# Altium schematic sheet Sheet3.SchDoc
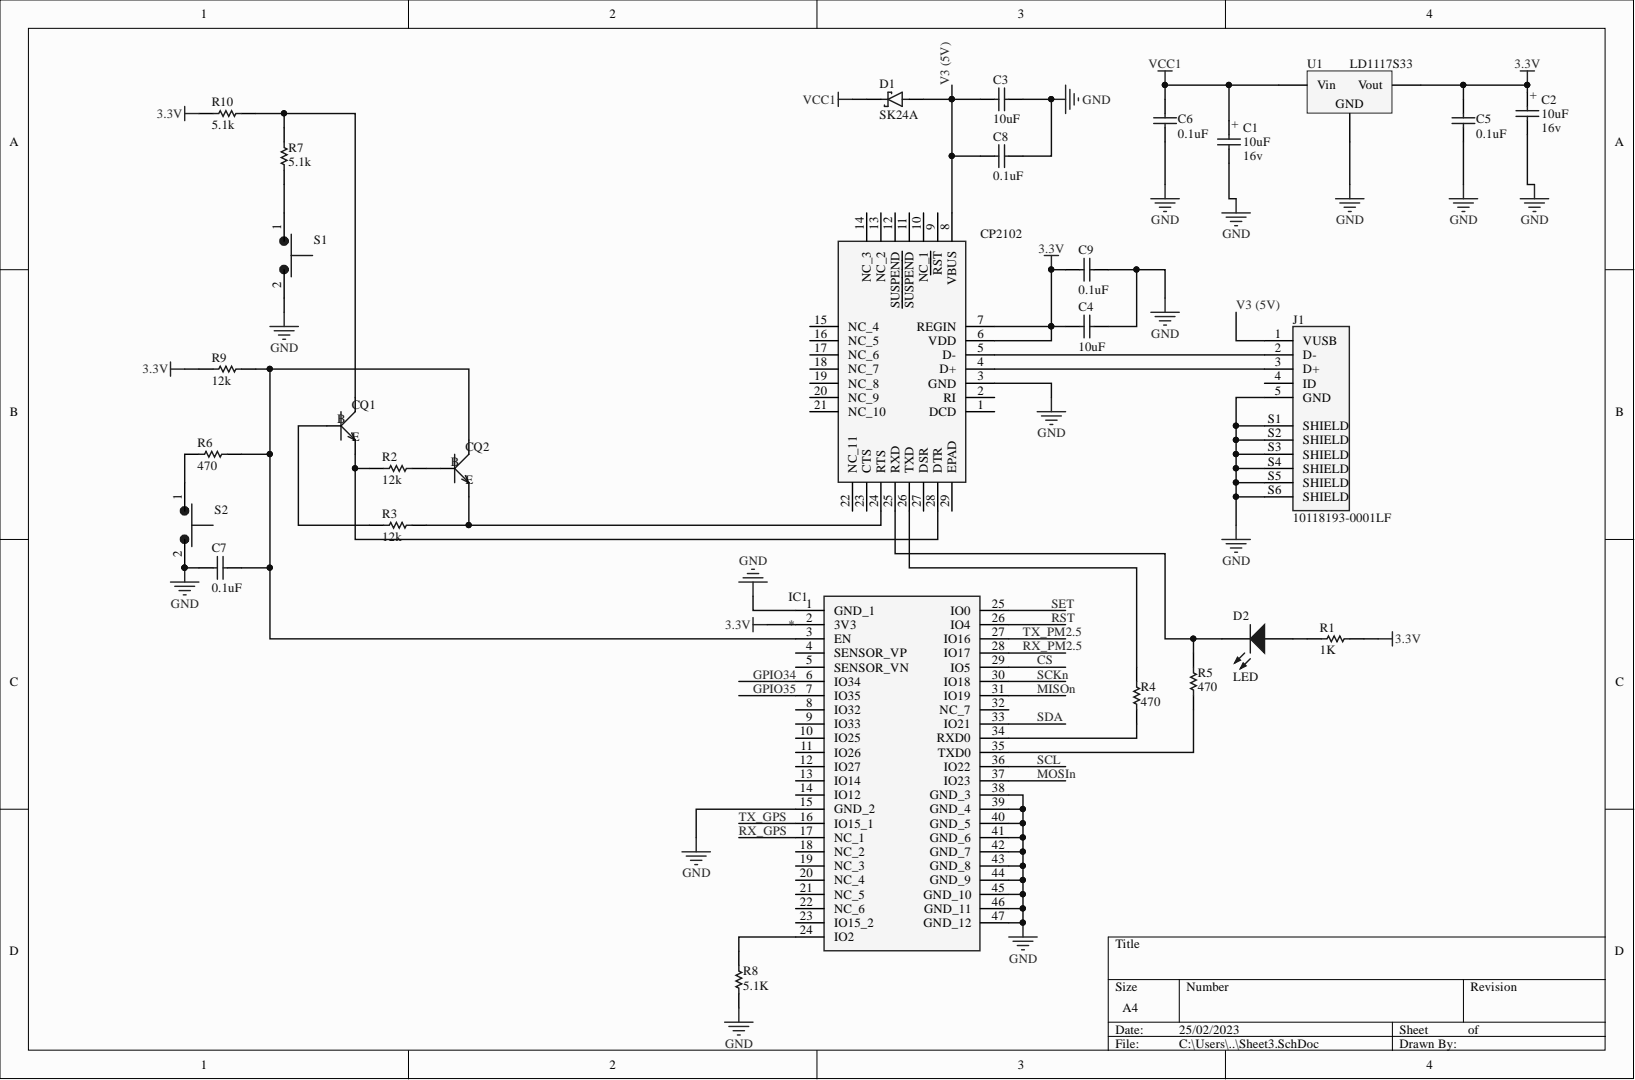
[diagram p0: Sheet3.SchDoc]

## Parts
C1  10uF  Volte: 16v  [MY_SMC_C]
C2  10uF  Volte: 16v  [MY_SMC_C]
C3  10uF  [MY_0603]
C4  10uF  [MY_0603]
C5  0.1uF  [MY_0603]
C6  0.1uF  [MY_0603]
C7  0.1uF  [MY_0603]
C8  0.1uF  [MY_0603]
C9  0.1uF  [MY_0603]
D1  SK24A  [DIOM4325X250N]
D2  LED  [MY_LED_0805]
IC1  (no value)  [ESP32WROOM32UEM113EH2800UH3Q0]
IC2  CP2102  [QFN50P500X500X100-29N-D]
J1  10118193-0001LF  [FCI_10118193-0001LF]
Q1  (no value)  [SOT-23]
Q2  (no value)  [SOT-23]
R1  1K  [MY_0603]
R2  12k  [MY_0603]
R3  12k  [MY_0603]
R4  470  [MY_0603]
R5  470  [MY_0603]
R6  470  [MY_0603]
R7  5.1k  [MY_0603]
R8  5.1K  [MY_0603]
R9  12k  [MY_0603]
R10  5.1k  [MY_0603]
S1  TL1015AF160QG  [SW_TL1015AF160QG]  (value not drawn)
S2  TL1015AF160QG  [SW_TL1015AF160QG]  (value not drawn)
U1  LD1117S33  [MY_SOT223]

## Nets
3.3V: C2.+ C4.2 C5.2 C9.2 IC1.2(3V3) IC2.6(VDD) IC2.7(REGIN) R1.2 R9.1 R10.1 U1.2
GND: C1.- C2.- C3.1 C4.1 C5.1 C6.1 C7.2 C8.1 C9.1 IC1.1(GND_1) IC1.15(GND_2) IC1.38(GND_3) IC1.39(GND_4) IC1.40(GND_5) IC1.41(GND_6) IC1.42(GND_7) IC1.43(GND_8) IC1.44(GND_9) IC1.45(GND_10) IC1.46(GND_11) IC1.47(GND_12) IC2.3(GND) J1.5(GND) J1.S1(SHIELD) J1.S2(SHIELD) J1.S3(SHIELD) J1.S4(SHIELD) J1.S5(SHIELD) J1.S6(SHIELD) R8.1 S1.2 S2.2 U1.1
VCC1: C1.+ C6.2 D1.C U1.3
CS: IC1.29(IO5)
GPIO34: IC1.6(IO34)
GPIO35: IC1.7(IO35)
MISOn: IC1.31(IO19)
MOSIn: IC1.37(IO23)
RST: IC1.26(IO4)
RX_GPS: IC1.17(NC_1)
RX_PM2.5: IC1.28(IO17)
SCKn: IC1.30(IO18)
SCL: IC1.36(IO22)
SDA: IC1.33(IO21)
SET: IC1.25(IO0)
TX_GPS: IC1.16(IO15_1)
TX_PM2.5: IC1.27(IO16)
V3 (5V): C3.2 C8.2 D1.A IC2.8(VBUS) J1.1(VUSB)
NetC7_1: C7.1 IC1.3(EN) Q2.3 R6.2 R9.2
NetD2_A: D2.A R1.1
NetD2_K: D2.K IC2.25(RXD) R5.2
NetIC1_24: IC1.24(IO2) R8.2
NetIC1_34: IC1.34(RXD0) R4.1
NetIC1_35: IC1.35(TXD0) R5.1
NetIC2_4: IC2.4(D+) J1.3(D+)
NetIC2_5: IC2.5(D-) J1.2(D-)
NetIC2_24: IC2.24(RTS) Q2.2 R3.2
NetIC2_26: IC2.26(TXD) R4.2
NetIC2_28: IC2.28(DTR) Q1.2 R2.1
NetQ1_1: Q1.1 R3.1
NetQ1_3: Q1.3 R7.2 R10.2
NetQ2_1: Q2.1 R2.2
NetR6_1: R6.1 S2.1
NetR7_1: R7.1 S1.1

Not connected: IC1 4(SENSOR_VP) 5(SENSOR_VN) 8(IO32) 9(IO33) 10(IO25) 11(IO26) 12(IO27) 13(IO14) 14(IO12) 18(NC_2) 19(NC_3) 20(NC_4) 21(NC_5) 22(NC_6) 23(IO15_2) 32(NC_7); IC2 1(DCD) 2(RI) 9(RST) 10(NC_1) 11(SUSPEND) 12(SUSPEND) 13(NC_2) 14(NC_3) 15(NC_4) 16(NC_5) 17(NC_6) 18(NC_7) 19(NC_8) 20(NC_9) 21(NC_10) 22(NC_11) 23(CTS) 27(DSR) 29(EPAD); J1 4(ID)

## Library data of the parts
C1 C2 = Cap Pol: Polar capacitor; ASiD Part Number: C-AS; Component Kind: Standard; Library Name: Mylib+Rev+4.IntLib; Value: 10uF
C3-C9 = Cap ceramic: None polar capacitor; ASiD Part Number: C-AS; Volte: 50v; Component Kind: Standard; Library Name: Mylib+Rev+4.IntLib
  C3 C4: Value: 10uF
  C5-C9: Value: 0.1uF
D1 = SK24A: 2A, 40V, Schottky Rectifier; MF: Taiwan Semiconductor; Availability: In Stock; Check_prices: https://www.snapeda.com/parts/SK24A/Taiwan+Semiconductor/view-part/?ref=eda; Component Kind: Standard; Library Name: SK24A.IntLib; MP: SK24A; Package: SMA Taiwan Semiconductor; Price: None; SnapEDA_Link: https://www.snapeda.com/parts/SK24A/Taiwan+Semiconductor/view-part/?ref=snap
D2 = LED: Typical INFRARED GaAs LED; ASiD Part Number: LED-AS; Component Kind: Standard; Library Name: Mylib+Rev+4.IntLib
IC1 = ESP32-WROOM-32UE_M113EH2800UH3Q0_: Integrated Circuit; Manufacturer_Name: Espressif Systems; Manufacturer_Part_Number: ESP32-WROOM-32UE(M113EH2800UH3Q0); Component Kind: Standard; Datasheet Link: https://www.mouser.co.uk/datasheet/2/891/esp32_wroom_32e_esp32_wroom_32ue_datasheet_en-1855879.pdf; Height: 3.35mm; Mouser Part Number: N/A; Mouser Price/Stock: https://www.mouser.co.uk/ProductDetail/Espressif-Systems/ESP32-WROOM-32UEM113EH2800UH3Q0?qs=vHuUswq2%252BswmjMZOJoYkgw%3D%3D
IC2 = CP2102: Integrated Circuit; Manufacturer_Name: Silicon Labs; Component Kind: Standard; Datasheet Link: https://componentsearchengine.com/Datasheets/1/CP2102.pdf; Height: 1mm; Manufacturer_Part_Number: CP2102; Mouser Part Number: 634-CP2102; Mouser Price/Stock: https://www.mouser.co.uk/ProductDetail/Silicon-Labs/CP2102?qs=ucY0lxHzU7615lOm95XT0w%3D%3D
J1 = 10118193-0001LF: USB - micro B USB 2.0 Receptacle Connector 5 Position Surface Mount, Right Angle; Through Hole; MF: FCI; Availability: In Stock; Check_prices: https://www.snapeda.com/parts/10118193-0001LF/Amphenol+FCI/view-part/?ref=eda; Component Kind: Standard; Library Name: 10118193-0001LF.IntLib; MP: 10118193-0001LF; Package: None; Price: None; Purchase-URL: https://pricing.snapeda.com/search/part/10118193-0001LF/?ref=eda; SnapEDA_Link: https://www.snapeda.com/parts/10118193-0001LF/Amphenol+FCI/view-part/?ref=snap
Q1 Q2 = SMD-TRANSISTORS-NPN-25V-500MW(SOT-23): Bipolar (BJT) Transistor NPN 25 V 500 mA 150MHz 300 mW Surface Mount SOT-23; MF: MDD; MP: S8050; Availability: In Stock; Check_prices: https://www.snapeda.com/parts/S8050/MDD/view-part/?ref=eda; Component Kind: Standard; Library Name: SMD-TRANSISTORS-NPN-25V-500MW_SOT-23_.IntLib; Package: None; Price: None; Purchase-URL: https://pricing.snapeda.com/search/part/S8050/?ref=eda; SnapEDA_Link: https://www.snapeda.com/parts/S8050/MDD/view-part/?ref=snap
R1-R10 = Res: Standard resistor; ASiD Part Number: Res-AS; Component Kind: Standard; Library Name: Mylib+Rev+4.IntLib
  R1: Value: 1K
  R2 R3 R9: Value: 12k
  R4-R6: Value: 470
  R7 R10: Value: 5.1k
  R8: Value: 5.1K
S1 S2 = TL1015AF160QG: Tactile Switch SPST-NO Top Actuated Surface Mount; Availability: Unavailable; Component Kind: Standard; Library Name: TL1015AF160QG.IntLib; MP: TL1015AF160QG; Package: None; Price: None
U1 = LM1117: Low Drop Voltage Reg; ASiD Part Number: LM1117-AS; Component Kind: Standard; Library Name: Mylib+Rev+4.IntLib
